# Altium schematic sheet reflowcontroller.SchDoc(reflowcontroller)
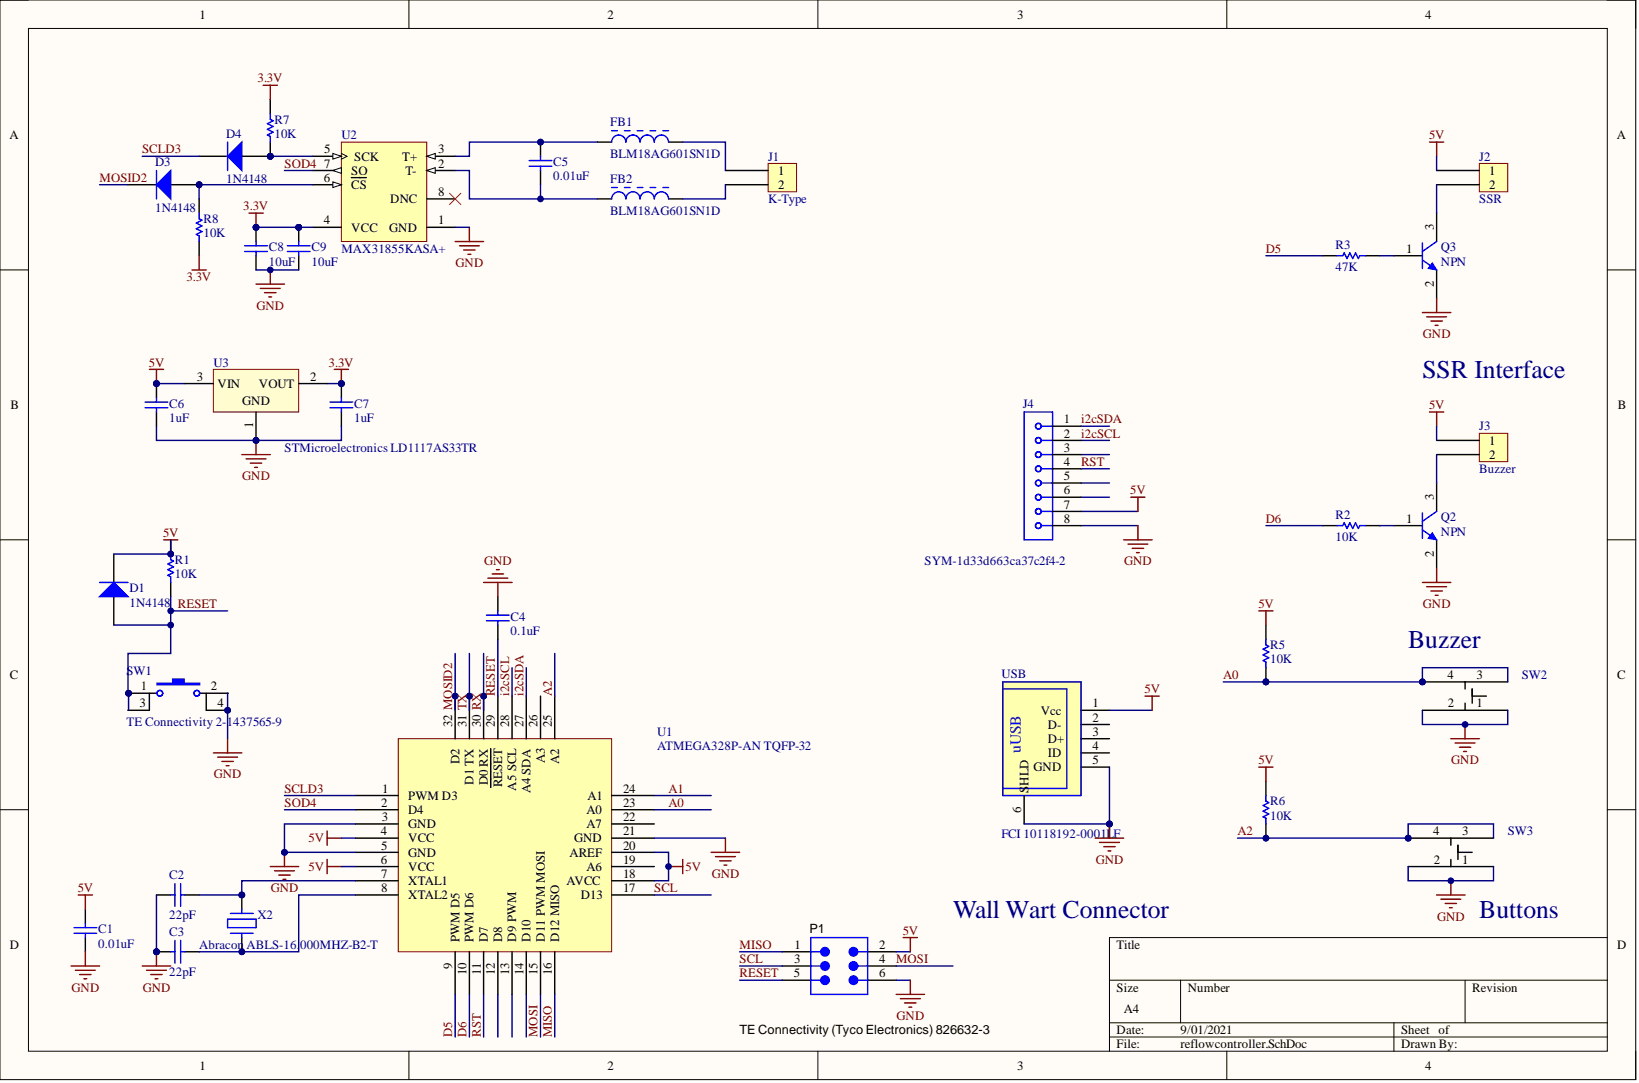
[diagram p0: reflowcontroller.SchDoc]

## Parts
C1  0.01uF
C2  22pF
C3  22pF
C4  0.1uF
C5  0.01uF
C6  1uF
C7  1uF
C8  10uF
C9  10uF
D1  1N4148
D3  1N4148
D4  1N4148
FB1  BLM18AG601SN1D
FB2  BLM18AG601SN1D
J1  K-Type
J2  SSR
J3  Buzzer
J4  SYM-1d33d663ca37c2f4-2
P1  TE Connectivity (Tyco Electronics) 826632-3
Q2  NPN
Q3  NPN
R1  10K
R2  10K
R3  47K
R5  10K
R6  10K
R7  10K
R8  10K
SW1  TE Connectivity 2-1437565-9
SW2  (no value)
SW3  (no value)
U1  ATMEGA328P-AN TQFP-32
U2  MAX31855KASA+
U3  STMicroelectronics LD1117AS33TR
USB  FCI 10118192-0001LF  Manufacturer Part Number: 10118192-0001LF
X2  Abracon ABLS-16.000MHZ-B2-T  Manufacturer Part Number: ABLS-16.000MHZ-B2-T

## Nets
3.3V: C7.2 C8.2 C9.2 R7.2 R8.1 U2.4(VCC) U3.2
5V: C1.2 C6.2 D1.2 J2.1 J3.1 J4.7 P1.2 R1.2 R5.2 R6.2 U1.4(VCC) U1.6(VCC) U1.18(AVCC) U1.20(AREF) U3.3 USB.1(Vcc)
GND: C1.1 C2.1 C3.1 C4.2 C6.1 C7.1 C8.1 C9.1 J4.8 P1.6 Q2.2 Q3.2 SW1.2 SW1.4 SW2.1 SW2.2 SW3.1 SW3.2 U1.3(GND) U1.5(GND) U1.21(GND) U2.1(GND) U3.1 USB.5(GND) USB.6(SHLD)
A0: R5.1 SW2.3 SW2.4 U1.23(A0)
A1: U1.24(A1)
A2: R6.1 SW3.3 SW3.4 U1.25(A2)
D5: R3.2 U1.9(PWM_D5)
D6: R2.2 U1.10(PWM_D6)
i2cSCL: J4.2 U1.28(A5_SCL)
i2cSDA: J4.1 U1.27(A4_SDA)
MISO: P1.1 U1.16(D12_MISO)
MOSI: P1.4 U1.15(D11_PWM_MOSI)
MOSID2: D3.2 U1.32(D2)
RESET: C4.1 D1.1 P1.5 R1.1 SW1.1 SW1.3 U1.29(RESET)
RST: J4.4 U1.11(D7)
RX: U1.30(D0_RX)
SCL: P1.3 U1.17(D13)
SCLD3: D4.2 U1.1(PWM_D3)
SOD4: U1.2(D4) U2.7(SO)
TX: U1.31(D1_TX)
NetC2_2: C2.2 U1.7(XTAL1) X2.2
NetC3_2: C3.2 U1.8(XTAL2) X2.1
NetC5_1: C5.1 FB2.1 U2.2(T-)
NetC5_2: C5.2 FB1.1 U2.3(T+)
NetD3_1: D3.1 R8.2 U2.6(CS)
NetD4_1: D4.1 R7.1 U2.5(SCK)
NetFB1_2: FB1.2 J1.1
NetFB2_2: FB2.2 J1.2
NetJ2_2: J2.2 Q3.3
NetJ3_2: J3.2 Q2.3
NetQ2_1: Q2.1 R2.1
NetQ3_1: Q3.1 R3.1

Not connected: J4 3 5 6; U1 12(D8) 13(D9_PWM) 14(D10) 19(A6) 22(A7) 26(A3); U2 8(DNC); USB 2(D-) 3(D+) 4(ID)

## Library data of the parts
C1-C9 = CMP-126079-7: 0805 Cap Ceramic; Manufacturer Part Number: 08051C103KAT2A; Capacitance: 1e-08 F; Capacitance Tolerance: ±10%; Case/Package (SI): 2012; Dielectric Characteristic: X7R; Dielectric Material: Ceramic Multilayer; Insulation Resistance: 1e+11 Ω; Voltage Rating (DC): 100.0 V; Case/Package: 0805; Component Kind: Standard; Library Name: CommunityVault; Mounting Style: Surface Mount; Number of Pins: 2; Octopart Url: https://octopart.com/08051c103kat2a-avx+interconnect+%2F+elco-46506200; OctopartID: 6e45a58aebfbb182; REACH SVHC Compliance: No SVHC; RoHS: Compliant; Size-Height: 0.00094 m; Size-Length: 0.0020066 m; Size-Thickness: 0.0009398 m; Size-Width: 0.0012446 m
D1 D3 D4 = CMP-791fb3587d52a6d8-19: Fast Switching Diode, 100V, 400mW, Surface Mount, SOD-123; Manufacturer Part Number: 1N4148W-7-F; Output Current: 300mA (max); Case/Package: SOD-123; Category: Discrete Semiconductor; Component Kind: Standard; Library Name: CommunityVault; Manufacturer PN: 1N4148W-7-F; Mounting Style: Surface Mount; Octopart URL: https://octopart.com/1n4148w-7-f-diodes+inc.-55386411; OctopartID: 791fb3587d52a6d8; RoHS: Compliant; Voltage Rating (DC): 100V
FB1 FB2 = CMP-14419471-3: Chip Ferrite Bead for General Use, 600 Ohm, 500 mA, -55 to 125 degC, 1.6 x 0.8 x 0.95 mm SMD, Tape and Reel; Current Rating: 0.2 A; Frequency: 100000000.0 Hz; Lead-Free Status: Lead Free; Number of Circuits: 1; Resistance: 600.0 ?; Shielding: No; Case/Package: 0603; Component Kind: Standard; Mounting Style: Surface Mount; Number of Pins: 2; Octopart Url: https://octopart.com/blm18ag601sn1d-murata-42207; OctopartID: 9cb755bd1d0e4370; REACH SVHC Compliance: No SVHC; RoHS: Compliant; Size-Height: 0.0008 m; Size-Length: 0.0016 m; Size-Width: 0.0008 m
  FB1: Library Name: CommunityVault
  FB2: Library Name: FavoritesLibrary
J1-J3 = CMP-74e0bf2ff245ab27-10: Connector, Through Hole, Header, 2, 2.54 mm 2pin; Component Kind: Standard; Library Name: CommunityVault; Orientation: Vertical; RoHS: Compliant
J4 = CMP-1d33d663ca37c2f4-4: MTA-100 8 Position Through-Hole Single Row Straight Wire to Board Header; Component Kind: Standard; Library Name: CommunityVault
P1 = CMP-18f2d1e5a96ec735-2: Conn Unshrouded Header HDR 6 POS 2.54mm Solder ST Thru-Hole Automotive Carton; Current Rating: 3.00 A; ELV Compliant: Compliant; Gender: Male; Lead-Free Status: Lead Free; Number of Contacts: 6; Component Kind: Standard; Contact Material: Phosphor Bronze; Contact Plating: Gold, Tin; Flammability Rating: UL 94 V-0; Housing Color: Green; Housing Material: Thermoplastic; Library Name: CommunityVault; Lifecycle Status: Active; Manufacturer Part Number: 826632-3; Mounting Style: PCB; Octopart Url: http://octopart.com/826632-3-te+connectivity+%2F+amp-1938682; OctopartID: 18f2d1e5a96ec735; Orientation: Vertical; Pin Pitch: 2.54 mm; REACH SVHC Compliance: No SVHC; RoHS: Compliant; Seller Landing  Url: https://octopart-clicks.com/click/track?country=US&ak=8157f166&sig=0f290fb&sid=5489&ppid=1938682&vpid=271695964&ct=offers
Q2 Q3 = CMP-89de4465c7e95619-1: Bipolar (BJT) Single Transistor, Switching, NPN, 40 V, 300 MHz, 250 mW, 200 mA, 100; Manufacturer Part Number: PMBT3904,215; Lead-Free Status: Lead Free; Case/Package: SOT-23; Component Kind: Standard; Library Name: CommunityVault; Lifecycle Status: Active; Mounting Style: Surface Mount; Number of Pins: 3; Octopart URL: http://octopart.com/pmbt3904%2C215-nexperia-78736209; OctopartID: 89de4465c7e95619; Packaging: Tape & Reel (TR), Cut Tape (CT); Polarity: NPN; REACH SVHC Compliance: No SVHC; RoHS: Compliant
R1-R3 R5-R8 = CMP-3650978-2: Thick Film Resistors - SMD 0402 402ohms 1% Tol; Lead-Free Status: Lead Free; Power Rating: 0.1 W; Resistance: 402.0 ?; Voltage Rating (DC): 50.0 V; Case/Package: 0402; Component Kind: Standard; Library Name: CommunityVault; Lifecycle Status: Not Listed by Manufacturer; Mounting Style: Surface Mount; Octopart Url: https://octopart.com/erj-2rkf4020x-panasonic-55411481; OctopartID: 358cffcb93f3ea4b; Packaging: Cut Tape (CT); REACH SVHC Compliance: No SVHC; Resistance Tolerance: ±1%; RoHS: Compliant; Size-Height: 0.00035 m; Size-Length: 0.001 m; Size-Width: 0.0005 m
SW1 = CMP-bcd77782e2b86310-2: Switch Tactile N.O. SPST Button J-Bend 0.02A 15VDC 3.5N SMD Automotive T/R; Manufacturer Part Number: EVQ-P2V02M; Contact Current Rating: 20.0 mA; Lead-Free Status: Lead Free; Component Kind: Standard; Library Name: CommunityVault; Lifecycle Status: Not Listed by Manufacturer; Mounting Style: Surface Mount; OctopartID: bcd77782e2b86310; Orientation: Vertical; Packaging: Reel; RoHS: Compliant; Size-Height: 2.10 mm; Size-Width: 3.50 mm
SW2 SW3 = CMP-aa6e6375560475a9-4: Switch Tactile N.O. SPST Round Button J-Bend 0.05A 32VDC 1VA 300000Cycles 3.5N SMD Automotive T/R; Manufacturer Part Number: KSC461JST2LFS; Contacts Type: SPST-NO; Lead-Free Status: Lead Free; Actuator Type: Button; Component Kind: Standard; Contact Material: Silver; Contact Plating: Silver; Library Name: CommunityVault; Mounting Style: Surface Mount; Octopart URL: http://octopart.com/ksc241jlfs-c%26k+components-1169676; OctopartID: aa6e6375560475a9; Orientation: Vertical; REACH SVHC Compliance: No SVHC; RoHS: Compliant; Size-Length: 6.20 mm; Size-Width: 6.20 mm
U1 = CMP-2386805-4: Embedded microcontroller ATMEGA328P-AN TQFP 32 (7x7) Microchip Technology 8-Bit 20 MHz I/O number 23; Case/Package: TQFP; Component Kind: Standard; Library Name: CommunityVault; Lifecycle Status: Not Listed by Manufacturer
U2 = CMP-1468732-4: Cold Junction Compensated Thermocouple to Digital Converter, 14 Bits Temperature Resolution, -40 to 125 degC, 8-Pin SOIC (S8+4), Pb-Free; Component Kind: Standard; Library Name: CommunityVault; Lifecycle Status: Not Listed by Manufacturer; Manufacturer Part Number: MAX31855KASA+; Mounting Style: Surface Mount; Octopart Url: https://octopart.com/max31855kasa%2B-maxim+integrated-44378229; OctopartID: 3d5cf6f3304fe29b
U3 = CMP-1210671-4: LD1117 Series 1 A 3.3 V Fixed Output Positive Voltage LDO Regulator - SOT-223; Dropout Voltage: 1.15 V; Input Voltage (DC): 10.0 V (max); Lead-Free Status: Lead Free; Number of Outputs: 1; Output Current: 1.00 A, 800 mA; Output Voltage: 3.30 V (max); Supply Voltage (DC): 15.0 V (max); Case/Package: SOT-223; Component Kind: Standard; Library Name: FavoritesLibrary; Lifecycle Status: Active; Manufacturer Part Number: LD1117AS33TR; Mounting Style: Surface Mount; Number of Pins: 3; Octopart URL: http://octopart.com/ld1117as33tr-stmicroelectronics-526713; OctopartID: 9b725270b76d44b5; Packaging: Cut Tape (CT); REACH SVHC Compliance: No SVHC; RoHS: Compliant
USB = CMP-2509ed477c75face-2: Conn Micro USB Type B RCP 5 POS 0.65mm Solder RA SMD 5 Terminal 1 Port T/R; Gender: Female; Lead-Free Status: Lead Free; Component Kind: Standard; Contact Material: Copper Alloy; Library Name: FavoritesLibrary; Mounting Style: Surface Mount; Octopart URL: http://octopart.com/10118192-0001lf-fci-21265434; OctopartID: 2509ed477c75face; Orientation: Right Angle; RoHS: Compliant
X2 = CMP-319bf8900463ee5c-1: Crystal 16MHz Â±20ppm (Tol) Â±50ppm (Stability) 18pF FUND 40Ohm 2-Pin HC-49/US SMD T/R; Equivalent Series Resistance (ESR): 40.0 Ω; Frequency: 16.0 MHz; Case/Package: HC-49; Component Kind: Standard; Library Name: CommunityVault; Number of Pins: 2; Octopart URL: https://octopart.com/abls-16.000mhz-b2-t-abracon-69790; OctopartID: 319bf8900463ee5c; Operating Temperature: 70.0 °C (max); Packaging: Tape & Reel (TR), Cut Tape (CT); Size-Height: 4.20 mm; Size-Length: 12.7 mm
